# Altium schematic sheet Battery_power.SchDoc
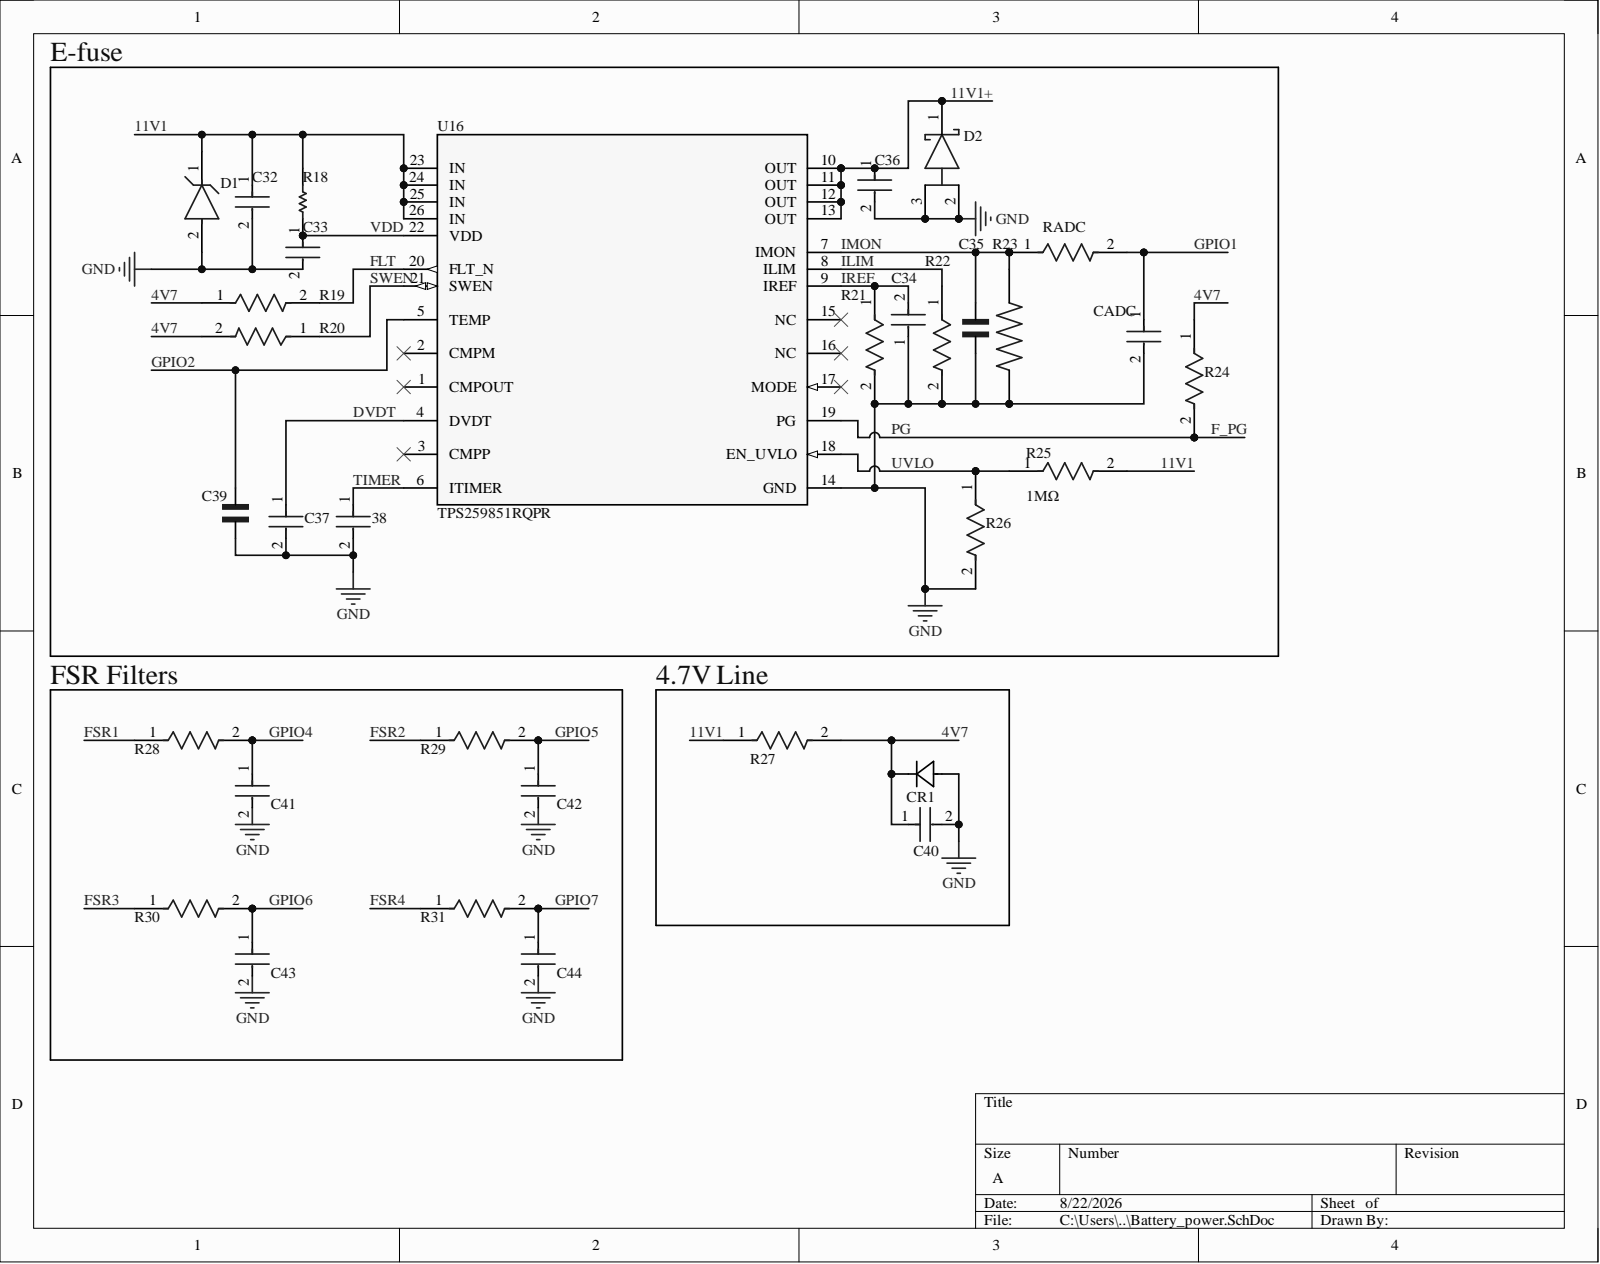
[diagram p0: Battery_power.SchDoc]

## Parts
38  GRM155R71H104KE14D  [FP-GRM155-0_05-IPC_B]  (value not drawn)
C32  CC0805KRX7R9BB104  [FP-CC0805-0_85-MFG]  (value not drawn)
C33  CC0805KKX7R9BB225  [FP-CC0805-0_85-MFG]  (value not drawn)
C34  C1608C0G1E472J080AA  [FP-C1608-080-0_1-IPC_A]  (value not drawn)
C35  GRM1885C1H101JA01D  [CAPC1608X90N]  (value not drawn)
C36  CC0805KKX7R9BB225  [FP-CC0805-0_85-MFG]  (value not drawn)
C37  GRM155R71H104KE14D  [FP-GRM155-0_05-IPC_B]  (value not drawn)
C39  GRM1885C1H101JA01D  [CAPC1608X90N]  (value not drawn)
C40  GRM155R71H104KE14D  [FP-GRM155-0_05-IPC_B]  (value not drawn)
C41  GRM155R71H104KE14D  [FP-GRM155-0_05-IPC_B]  (value not drawn)
C42  GRM155R71H104KE14D  [FP-GRM155-0_05-IPC_B]  (value not drawn)
C43  GRM155R71H104KE14D  [FP-GRM155-0_05-IPC_B]  (value not drawn)
C44  GRM155R71H104KE14D  [FP-GRM155-0_05-IPC_B]  (value not drawn)
CADC  CGA4C2C0G1H822J060AA  [FP-CGA4J-IPC_A]  (value not drawn)
CR1  BZT52C4V7-7-F  [SOD123_DIO]  (value not drawn)
D1  SMBJ12A  [FP-SMBJ-MFG]  (value not drawn)
D2  PDS1040-13  [FP-PowerDI5-MFG]  (value not drawn)
R18  CRCW040210R0FKED  [RESC1005X40X25NL05T05]  (value not drawn)
R19  CRCW040210K0FKEDC  [FP-CRCW0402-C-e3-IPC_C]  (value not drawn)
R20  CRCW0402100KFKED  [FP-CRCW0402-e3-IPC_C]  (value not drawn)
R21  RT0402BRD0748K7L  [FP-RT0402-MFG]  (value not drawn)
R22  RC0402FR-07499RL  [FP-RC0402-0_4-IPC_A]  (value not drawn)
R23  ERA3AEB102V  [RES_ERA3AEB102V]  (value not drawn)
R24  CRCW040210K0FKEDC  [FP-CRCW0402-C-e3-IPC_C]  (value not drawn)
R25  Resistance: 1MΩ  [CRCW0402-e3_MFG]  (hidden comment: CRCW04021M00FKED)
R26  CRCW0402121KFKED  [FP-CRCW0402-e3-MFG]  (value not drawn)
R27  RC0603FR-0710KL  [FP-RC0603-0_55-IPC_C]  (value not drawn)
R28  CRCW08054K70FKEA  [FP-CRCW0805-e3-IPC_B]  (value not drawn)
R29  CRCW08054K70FKEA  [FP-CRCW0805-e3-IPC_B]  (value not drawn)
R30  CRCW08054K70FKEA  [FP-CRCW0805-e3-IPC_B]  (value not drawn)
R31  CRCW08054K70FKEA  [FP-CRCW0805-e3-IPC_B]  (value not drawn)
RADC  CRCW08054K70FKEA  [FP-CRCW0805-e3-IPC_B]  (value not drawn)
U16  TPS259851RQPR  [RQP0026A-MFG]

## Nets
GND: 38.2 C32.2 C33.2 C34.1 C35.1 C36.2 C37.2 C39.1 C40.2 C41.2 C42.2 C43.2 C44.2 CADC.2 CR1.2 D1.2 D2.2 D2.3 R21.2 R22.2 R23.1 R26.2 U16.14(GND)
4V7: C40.1 CR1.1 R19.1 R20.2 R24.1 R27.2
11V1: C32.1 D1.1 R18.1 R25.2 R27.1 U16.23(IN) U16.24(IN) U16.25(IN) U16.26(IN)
11V1+: C36.1 D2.1 U16.10(OUT) U16.11(OUT) U16.12(OUT) U16.13(OUT)
DVDT: C37.1 U16.4(DVDT)
F_PG: R24.2 U16.19(PG)
FLT: R19.2 U16.20(FLT_N)
FSR1: R28.1
FSR2: R29.1
FSR3: R30.1
FSR4: R31.1
GPIO1: CADC.1 RADC.2
GPIO2: C39.2 U16.5(TEMP)
GPIO4: C41.1 R28.2
GPIO5: C42.1 R29.2
GPIO6: C43.1 R30.2
GPIO7: C44.1 R31.2
ILIM: R22.1 U16.8(ILIM)
IMON: C35.2 R23.2 RADC.1 U16.7(IMON)
IREF: C34.2 R21.1 U16.9(IREF)
SWEN: R20.1 U16.21(SWEN)
TIMER: 38.1 U16.6(ITIMER)
UVLO: R25.1 R26.1 U16.18(EN_UVLO)
VDD: C33.1 R18.2 U16.22(VDD)

Not connected: U16 1(CMPOUT) 2(CMPM) 3(CMPP) 15(NC) 16(NC) 17(MODE)

## Library data of the parts
38 C37 C40-C44 = CMP-2008-04639-2: Chip Multilayer Ceramic Capacitors for General Purpose, 0402, 0.10uF, X7R, 15%, 10%, 50V; Capacitance: 100nF; Dissipation Factor: 0.025%; Case Code (Imperial): 0402; Case Code (Metric): 1005; Case/Package: 0402; Component Kind: Standard; Depth: 0.5mm; Dielectric: X7R; Dielectric Material: Ceramic; Height: 0.5mm; Lead Free: Lead Free; Length: 1mm; Library Name: Altium Content Vault; Material: Ceramic; Max Operating Temperature: 125°C; Min Operating Temperature: -55°C; Mount: Surface Mount; Package Quantity: 10000; Packaging: Tape and Reel; REACH SVHC: No SVHC; Resistor Type: Ceramic; Termination: SMD/SMT; Thickness: 0.022inch; Tolerance: 10%; Voltage: 50V; Voltage Rating: 50V; Voltage Rating (DC): 50V; Weight: 0.000212oz; Width: 0.5mm
C32 = CMP-2100-03606-3: CAP CER 0.1UF 50V X7R 0805; Manufacturer: Yageo; Capacitance: 100 nF; Material: Ceramic; Tolerance: 0.1; Value: 100 nF; Automotive: No; Category: Cap; Component Kind: Standard; ComponentLink2Description: Datasheet; ComponentLink2URL: http://www.yageo.com/documents/recent/UPY-GPHC_Y5V_6.3V-to-50V_8.pdf; Device Class L1: Passive Components; Device Class L2: Capacitors; Device Class L3: Ceramic Capacitors; Digikey Description: CAP CER 0.1UF 50V X7R 0805; Footprint Url: http://www.yageo.com/exep/pages/download/literatures/UPY-C_GEN_24.pdf; Height: 0.95mm; Ipc Land Pattern Name: CAPC200125X85; Lead Free: Yes; Library Name: Altium Content Vault; Manufacturer Part Number: CC0805KRX7R9BB104; Mouser Description: Multilayer Ceramic Capacitors MLCC - SMD/SMT 100nF 50V X7R 10%; Octopart: https://octopart.com/cc0805krx7r9bb104-yageo-192993; Package: CC0805-0.85; RoHS: TRUE; Temperature: -30°C to +85°C; Temperature Characteristic: X7R; Temperature Range High: +85°C; Temperature Range Low: -30°C; Voltage Rating: 50 V
C33 C36 = CMP-03422-001390-1: CAP CER 2.2UF 50V X7R 0805; Manufacturer: Yageo; Material: Ceramic; Tolerance: 0.1; Automotive: No; Capacitance: 2.2 uF; Category: Cap; Component Kind: Standard; ComponentLink2Description: Datasheet; ComponentLink2URL: http://www.yageo.com/documents/recent/UPY-GPHC_Y5V_6.3V-to-50V_8.pdf; Device Class L1: Passive Components; Device Class L2: Capacitors; Device Class L3: Ceramic Capacitors; Digikey Description: CAP CER 2.2UF 50V X7R 0805; Footprint Url: http://www.yageo.com/exep/pages/download/literatures/UPY-C_GEN_24.pdf; Height: 0.95mm; Ipc Land Pattern Name: CAPC200125X85; Lead Free: Yes; Library Name: Altium Content Vault; Manufacturer Part Number: CC0805KKX7R9BB225; Mouser Description: 0805 50 V 2.2 uF ±10% Tolerance X7R Multilayer Ceramic Capacitor; Octopart: https://octopart.com/cc0805kkx7r9bb225-yageo-51849248; Package: CC0805-0.85; RoHS: TRUE; Temperature: -30°C to +85°C; Temperature Characteristic: X7R; Temperature Range High: +85°C; Temperature Range Low: -30°C; Value: 2.2 uF; Voltage Rating: 50 V
C34 = CMP-08246-004785-1: Multilayer Ceramic Capacitors 4.7nF ±5% 25V C0G SMD 0603; Capacitance: 4.7nF; Case Code (Imperial): 0603; Case Code (Metric): 1608; Case/Package: 0603; Component Kind: Standard; Construction: Flat; Depth: 0.8mm; Dielectric: C0G; Dielectric Material: Ceramic; Height: 0.8mm; Lead Free: Lead Free; Length: 1.6mm; Library Name: Altium Content Vault; Max Operating Temperature: 125°C; Min Operating Temperature: -55°C; Mount: Surface Mount; Number of Pins: 2; Package Quantity: 1; Packaging: Tape and Reel; Radiation Hardening: No; RoHS Compliant: Yes; Series: C; Termination: SMD/SMT; Thickness: 0.037inch; Tolerance: 5%; Voltage Rating: 25V; Voltage Rating (DC): 25V; Weight: 7.1E-05oz; Width: 0.031inch
C35 C39 = CMP-001-00083-1: SMD Capacitor C0G(EIA) with Capacitance: 100pF Tol. 5%. Rated Voltage: 50Vdc; MF: Murata Electronics; Case/Package: 1608 Murata; Check_prices: https://www.snapeda.com/parts/GRM1885C1H101JA01D/Murata+Electronics+North+America/view-part/?ref=eda; Component Kind: Standard; Component Type: Standard; Design Item ID: GRM1885C1H101JA01D; MP: GRM1885C1H101JA01D; Pins: 2; SnapEDA_Link: https://www.snapeda.com/parts/GRM1885C1H101JA01D/Murata+Electronics+North+America/view-part/?ref=snap; Source: GRM1885C1H101JA01D.SchLib; Value: 100pF; Library Name: Bryan Heddle
CADC = CMP-08246-000542-1: Cap Ceramic 8.2nF 50V C0G 5% Pad SMD 0805 +125°C Automotive T/R; Capacitance: 8.2nF; Case Code (Imperial): 0805; Case Code (Metric): 2012; Case/Package: 0805; Component Kind: Standard; Composition: Ceramic; Depth: 1.25mm; Dielectric: C0G; Height: 0.6mm; Length: 2mm; Library Name: Altium Content Vault; Max Operating Temperature: 125°C; Min Operating Temperature: -55°C; Mount: Surface Mount; Package Quantity: 1; Packaging: Tape and Reel; Ratings: AEC-Q200; REACH SVHC: No SVHC; Termination: SMD/SMT; Thickness: 0.028inch; Tolerance: 5%; Voltage Rating: 50V; Voltage Rating (DC): 50V; Weight: 0.000194oz; Width: 0.049inch
CR1 = BZT52C4V7-7-F: No Description Available; Manufacturer_Name: Diodes Inc; Component Kind: Standard; Library Name: BZT52C4V7-7-F.IntLib; Manufacturer_Part_Number: BZT52C4V7-7-F; Copyright: Copyright (C) 2026 Ultra Librarian. All rights reserved.
D1 = CMP-2000-07093-2: TVS DIODE 12V 19.9V DO214AA; Manufacturer: Littelfuse; Breakdown Voltage: 13.3V; Direction: Unidirectional; Max Junction Temp: +150°C; Number Of Channels: 1; Peak Pulse Current: 30.2A; Peak Pulse Power: 600W; Automotive: No; Category: Diode; Clamping Voltage: 19.9V; Component Kind: Standard; ComponentLink2Description: Datasheet; ComponentLink2URL: https://www.littelfuse.com/~/media/electronics/datasheets/tvs_diodes/littelfuse_tvs_diode_smbj_datasheet.pdf.pdf; Device Class L1: Circuit Protection; Device Class L2: TVS Diodes; Device Class L3: unset; Digikey Description: TVS DIODE 12V 19.9V DO214AA; Height: 2.61mm; Lead Free: Yes; Library Name: Altium Content Vault; Manufacturer Part Number: SMBJ12A; Mouser Description: Diode; 30.2 A (Max.); 13.30 V (Min.) @ 1 mA; 5 uA (Max.) @ 12 V; 100 A (Max.); Octopart: https://octopart.com/smbj12a-littelfuse-28708; Package: SMBJ; Reverse Standoff Voltage: 12V; RoHS: TRUE; Temperature: -65°C to +150°C; Temperature Range High: +150°C; Temperature Range Low: -65°C
D2 = CMP-12790-000047-1: DIODE SCHOTTKY 40V 10A POWERDI5; Manufacturer: Diodes Inc.; Automotive Grade: NA; Capacitance: 1600pF; Max Junction Temp: +180°C; Max Surge Forward Current: 275A; Peak Reverse Current: 0.03mA; Standoff Height: 0mm; Value: 1600pF; Automotive: No; Category: Diode; Component Kind: Standard; ComponentLink2Description: Datasheet; ComponentLink2URL: https://www.diodes.com/assets/Datasheets/Ds30538.pdf; Device Class L1: Discrete Semiconductors; Device Class L2: Diodes; Device Class L3: Schottky Diodes; Digikey Description: DIODE SCHOTTKY 40V 10A POWERDI5; Footprint Url: https://www.diodes.com/assets/Package-Files/PowerDI5.pdf; Forward Current: 10A; Height: 1.15mm; Lead Free: Yes; Library Name: Altium Content Vault; Manufacturer Part Number: PDS1040-13; Max Repetitive Reverse Voltage: 40V; Mouser Description: Schottky Diodes & Rectifiers 10A 40V LFF; Octopart: https://octopart.com/pds1040-13-diodes+inc.-332539; Package: PowerDI5; Reverse Voltage: 40V; RoHS: TRUE; Temperature: -65°C to +180°C; Temperature Range High: +180°C; Temperature Range Low: -65°C
R18 = CMP-2002-08143-1: Manufacturer: Vishay Dale; Component Kind: Standard; Library Name: Altium Content Vault; Part Number: CRCW040210R0FKED
R19 R24 = CMP-02407-012085-1: RES 10K OHM 1% 1/16W 0402; Power Rating: 62.5mW; Resistance: 10kR; Temperature Coefficient: 100ppm/°C; Case/Package: Molded; Component Kind: Standard; Contact Plating: Tin; Lead Free: Lead Free; Length: 1mm; Library Name: Altium Content Vault; Max Operating Temperature: 155°C; Min Operating Temperature: -55°C; Mount: Surface Mount; Resistor Type: Thick Film; RoHS Compliant: Yes; Termination: Wraparound; Tolerance: 1%
R20 = CMP-2002-08123-3: RES Thick Film, 100kΩ, 1%, 0.063W, 100ppm/°C, 0402; Max Power Dissipation: 63mW; Resistance: 100kR; Voltage Rating: 50V; Case Code (Imperial): 0402; Case Code (Metric): 1005; Case/Package: 0402; Component Kind: Standard; Composition: Thick Film; Contact Plating: Tin; Depth: 0.5mm; Features: Automotive AEC-Q200; Height: 0.35mm; Lead Free: Lead Free; Length: 1mm; Library Name: Altium Content Vault; Max Operating Temperature: 155°C; Military Standard: Not; Min Operating Temperature: -55°C; Mount: Surface Mount; Number of Pins: 2; Number of Terminations: 2; Package Quantity: 10000; Packaging: Tape and Reel; Power Rating: 63mW; Radiation Hardening: No; Temperature Coefficient: 100ppm/°C; Termination: SMD/SMT; Tolerance: 1%; Voltage Rating (DC): 50V; Weight: 2.3E-05oz; Width: 0.02inch
R21 = CMP-2002-02883-2: RES SMD 48.7K OHM 0.1% 1/16W; Manufacturer: Yageo; Power Rating: 0.063W; Resistance: 48.7KΩ; Temperature Coefficient: 25 ppm/°C; Tolerance: 0.001; Value: 48.7KΩ; Voltage Rating: 50V; Automotive: No; Category: Res; Component Kind: Standard; ComponentLink2Description: Datasheet; ComponentLink2URL: http://www.yageo.com/documents/recent/PYu-RT_1-to-0.01_RoHS_L_9.pdf; Composition: Thin Film; Device Class L1: Passive Components; Device Class L2: Resistors; Device Class L3: Chip SMD Resistors; Digikey Description: RES SMD 48.7K OHM 0.1% 1/16W; Footprint Url: http://www.yageo.com/exep/pages/download/literatures/PYu-R_Mount_10.pdf; Height: 0.35mm; Ipc Land Pattern Name: RESC10050X30; Lead Free: Yes; Library Name: Altium Content Vault; Manufacturer Part Number: RT0402BRD0748K7L; Mouser Description: Thin Film Resistors - SMD 1/16W 48.7K ohm .1% 25ppm; Octopart: https://octopart.com/rt0402brd0748k7l-yageo-1374792; Package: RT0402; RoHS: TRUE; Series: RT; Temperature: -55°C to +155°C; Temperature Range High: +155°C; Temperature Range Low: -55°C
R22 = CMP-2002-07708-2: RES 499 OHM 1% 1/16W 0402; Max Power Dissipation: 63mW; Power Rating: 62.5mW; Resistance: 499R; Temperature Coefficient: 100ppm/°C; Voltage Rating: 50V; Case Code (Imperial): 0402; Case Code (Metric): 1005; Case/Package: 0402; Component Kind: Standard; Construction: Rectangular; Depth: 0.5mm; Features: Moisture Resistant; Height: 0.016inch; Lead Free: Lead Free; Length: 0.039inch; Library Name: Altium Content Vault; Max Operating Temperature: 155°C; Military Standard: Not; Min Operating Temperature: -55°C; Number of Terminations: 2; Package Quantity: 1; Packaging: Tape and Reel; REACH SVHC: No SVHC; Resistance Tolerance: 1%; Resistor Type: Thick Film; RoHS Compliant: Yes; Series: RC; Size Code: 0402; Termination: SMD/SMT; Tolerance: 1%; Voltage Rating (DC): 50V; Width: 0.02inch
R23 = CMP-009-00180-1: Resistor, SMD 1 kOhm 0603 0.1 %; MF: Panasonic; MP: ERA-3AEB102V; Value: 1k; Case/Package: 1608-2 Panasonic; Check_prices: https://www.snapeda.com/parts/ERA-3AEB102V/Panasonic%20Electronic%20Components/view-part/260476/?ref=eda; Component Kind: Standard; Component Type: Standard; Design Item ID: ERA3AEB102V; Digi-Key_Part_Number: P1.0KDBCT-ND; Pins: 2; SnapEDA_Link: https://www.snapeda.com/parts/ERA-3AEB102V/Panasonic%20Electronic%20Components/view-part/260476/?ref=snap; Source: ERA3AEB102V.SchLib; Library Name: Bryan Heddle
R25 = CMP-2002-08314-3: 63mW Thick Film Resistors 75V ±1% ±100ppm/℃ 1MΩ 0402 Chip Resistor - Surface Mount ROHS; Temperature Coefficient: 100ppm/°C; Voltage Rating: 50V; Case Code (Imperial): 0402; Case/Package: 0402; China RoHS: Non-Compliant; Component Kind: Standard; ComponentLink1Description: Manufacturer's Website; ComponentLink1URL: http://www.vishay.com; ComponentLink2Description: Datasheet URL; ComponentLink2URL: http://www.vishay.com/docs/20035/dcrcwe3.pdf; Composition: Thick Film; Contact Plating: Tin; Depth: 500µm; Features: Automotive AEC-Q200; Height: 350µm; HelpURL: http://www.vishay.com/docs/20035/dcrcwe3.pdf; Lead Free: Lead Free; Length: 1mm; Library Name: Altium Content Vault; Lifecycle Status: Production; Max Operating Temperature: 155°C; Max Power Dissipation: 63mW; Military Standard: Not; Min Operating Temperature: -55°C; Mount: Surface Mount; Number of Pins: 2; Number of Terminals: 2; Number of Terminations: 2; Packaging: Cut Tape; Power Rating: 63mW; Radiation Hardening: No; Ratings: AEC-Q200; REACH SVHC: Yes; RoHS: Compliant; Schedule B: 8533210045, 8533210045; Termination: SMD/SMT; Tolerance: 1%; Voltage Rating (DC): 50V; Weight: 652.039032µg; Width: 500µm; Working Voltage: 50V
R26 = CMP-2002-08171-2: RES Thick Film, 121kΩ, 1%, 0.063W, 100ppm/°C, 0402; Max Power Dissipation: 63mW; Resistance: 121kR; Voltage Rating: 50V; Case Code (Imperial): 0402; Case Code (Metric): 1005; Case/Package: 0402; Component Kind: Standard; Composition: Thick Film; Contact Plating: Tin; Depth: 0.5mm; Features: Automotive AEC-Q200; Height: 0.35mm; Lead Free: Lead Free; Length: 1mm; Library Name: Altium Content Vault; Max Operating Temperature: 155°C; Military Standard: Not; Min Operating Temperature: -55°C; Number of Terminations: 2; Package Quantity: 10; Packaging: Tape and Reel; Power Rating: 63mW; Radiation Hardening: No; Temperature Coefficient: 100ppm/°C; Termination: SMD/SMT; Tolerance: 1%; Voltage Rating (DC): 50V; Weight: 2.3E-05oz; Width: 0.5mm
R27 = CMP-2100-03664-2: RES 10K OHM 1% 1/10W 0603; Max Power Dissipation: 100mW; Resistance: 10kR; Temperature Coefficient: 100ppm/°C; Voltage Rating: 75V; Voltage Rating (DC): 50V; Case Code (Imperial): 0603; Case Code (Metric): 1608; Case/Package: 0603; Component Kind: Standard; Construction: Rectangular; Depth: 0.8mm; Features: Moisture Resistant; Height: 0.45mm; Lead Free: Lead Free; Lead Pitch: 0.3mm; Length: 1.6mm; Library Name: Altium Content Vault; Max Operating Temperature: 155°C; Military Standard: Not; Min Operating Temperature: -55°C; Number of Pins: 2; Number of Terminations: 2; Packaging: Tape and Reel; Power Rating: 100mW; Resistance Tolerance: 1%; Resistor Type: Thick Film; RoHS Compliant: Yes; Series: RC; Size Code: 0603; Termination: SMD/SMT; Tolerance: 1%; Weight: 7.1E-05oz; Width: 0.8mm
R28-R31 RADC = CMP-2100-03615-2: RES Thick Film, 4.7kΩ, 1%, 0.125W, 100ppm/°C, 0805; Max Power Dissipation: 125mW; Resistance: 4.7kR; Voltage Rating: 150V; Case Code (Imperial): 0805; Case Code (Metric): 2012; Case/Package: 0805; Component Kind: Standard; Composition: Thick Film; Contact Plating: Tin; Depth: 1.25mm; Features: Automotive AEC-Q200; Height: 0.45mm; Lead Free: Lead Free; Length: 2mm; Library Name: Altium Content Vault; Max Operating Temperature: 155°C; Military Standard: Not; Min Operating Temperature: -55°C; Number of Terminations: 2; Package Quantity: 10; Packaging: Tape and Reel; Power Rating: 125mW; Radiation Hardening: No; Temperature Coefficient: 100ppm/°C; Termination: SMD/SMT; Tolerance: 1%; Voltage Rating (DC): 150V; Weight: 0.000194oz; Width: 1.25mm
U16 = CMP-027-000000-2: 4.5-V to 16-V, 0.59-mÎ©, 80-A stackable compact eFuse with accurate and fast current monitor 26-VQFN-HR -40 to 125; Manufacturer_Name: Texas Instruments; Component Kind: Standard; Design Item ID: TPS259851RQPR; Manufacturer_Part_Number: TPS259851RQPR; RefDes: RefDes; Source: TPS259851RQPR.SchLib; TYPE: TYPE; Copyright: Copyright (C) 2025 Ultra Librarian. All rights reserved.; Library Name: Bryan Heddle
